# Altium schematic sheet CUBETTO-PT100-DA-PTC-.SchDoc(CUBETTO-PT100-DA-PTC-)
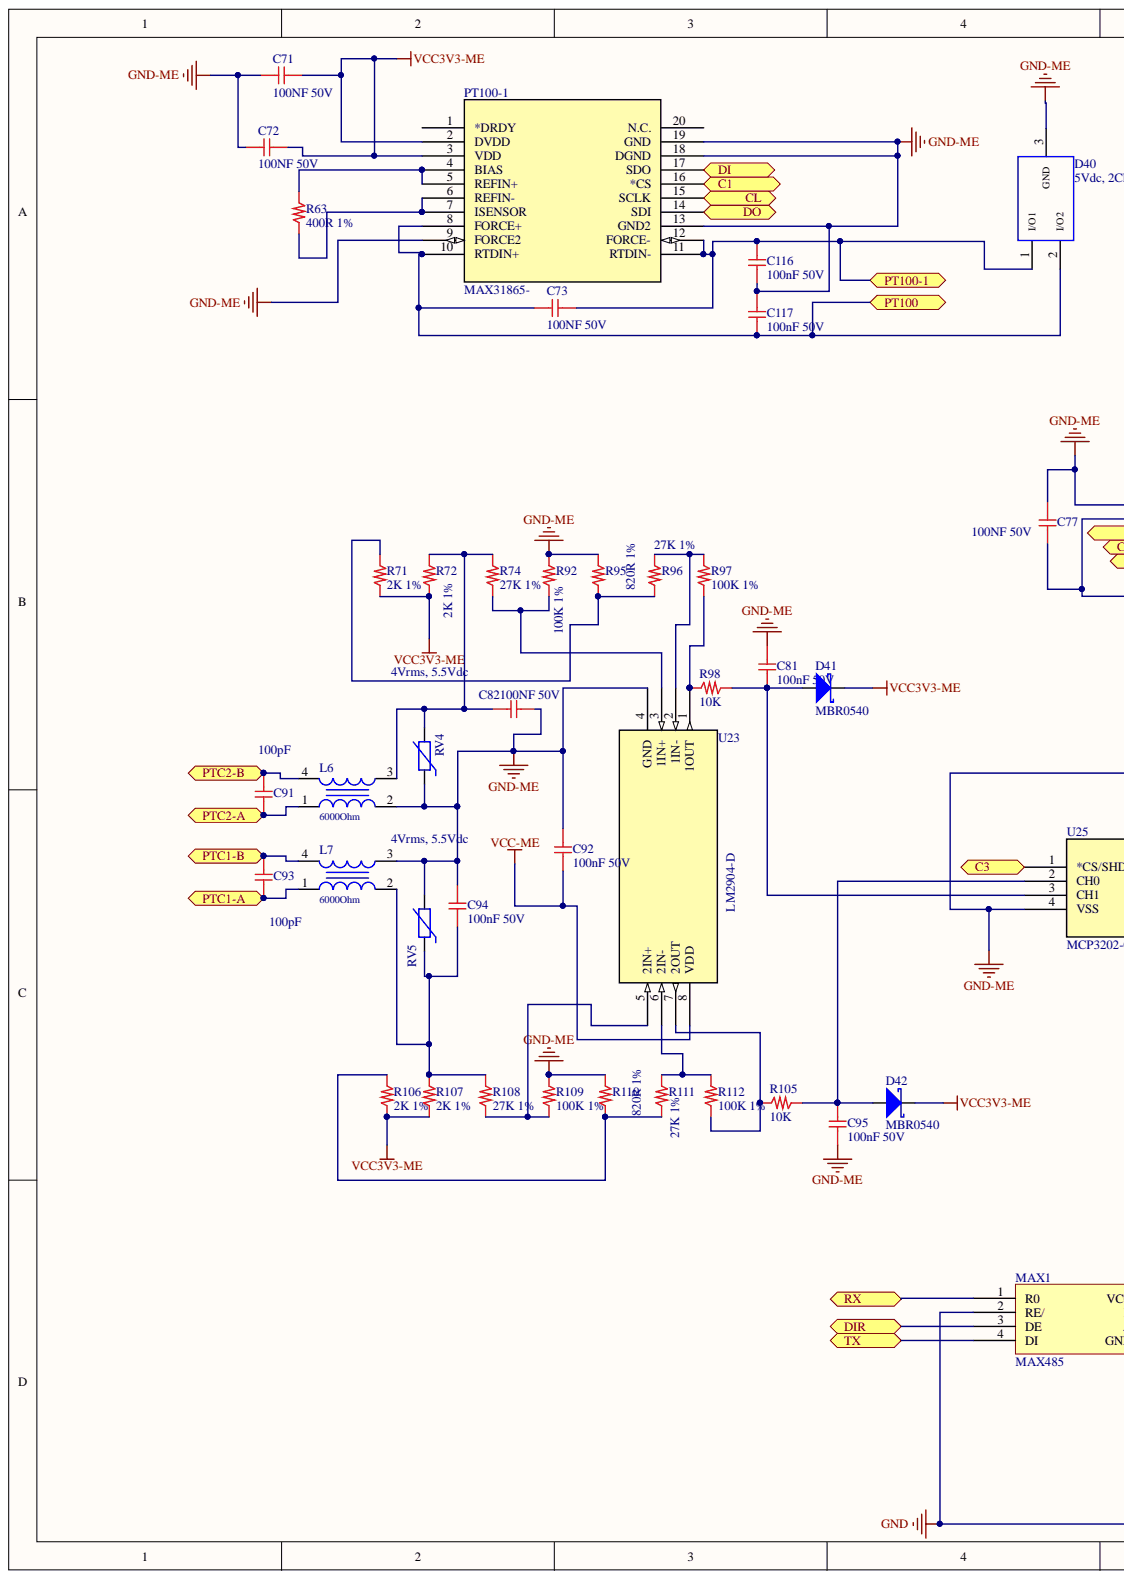
[diagram p0: CUBETTO-PT100-DA-PTC-.SchDoc, left]
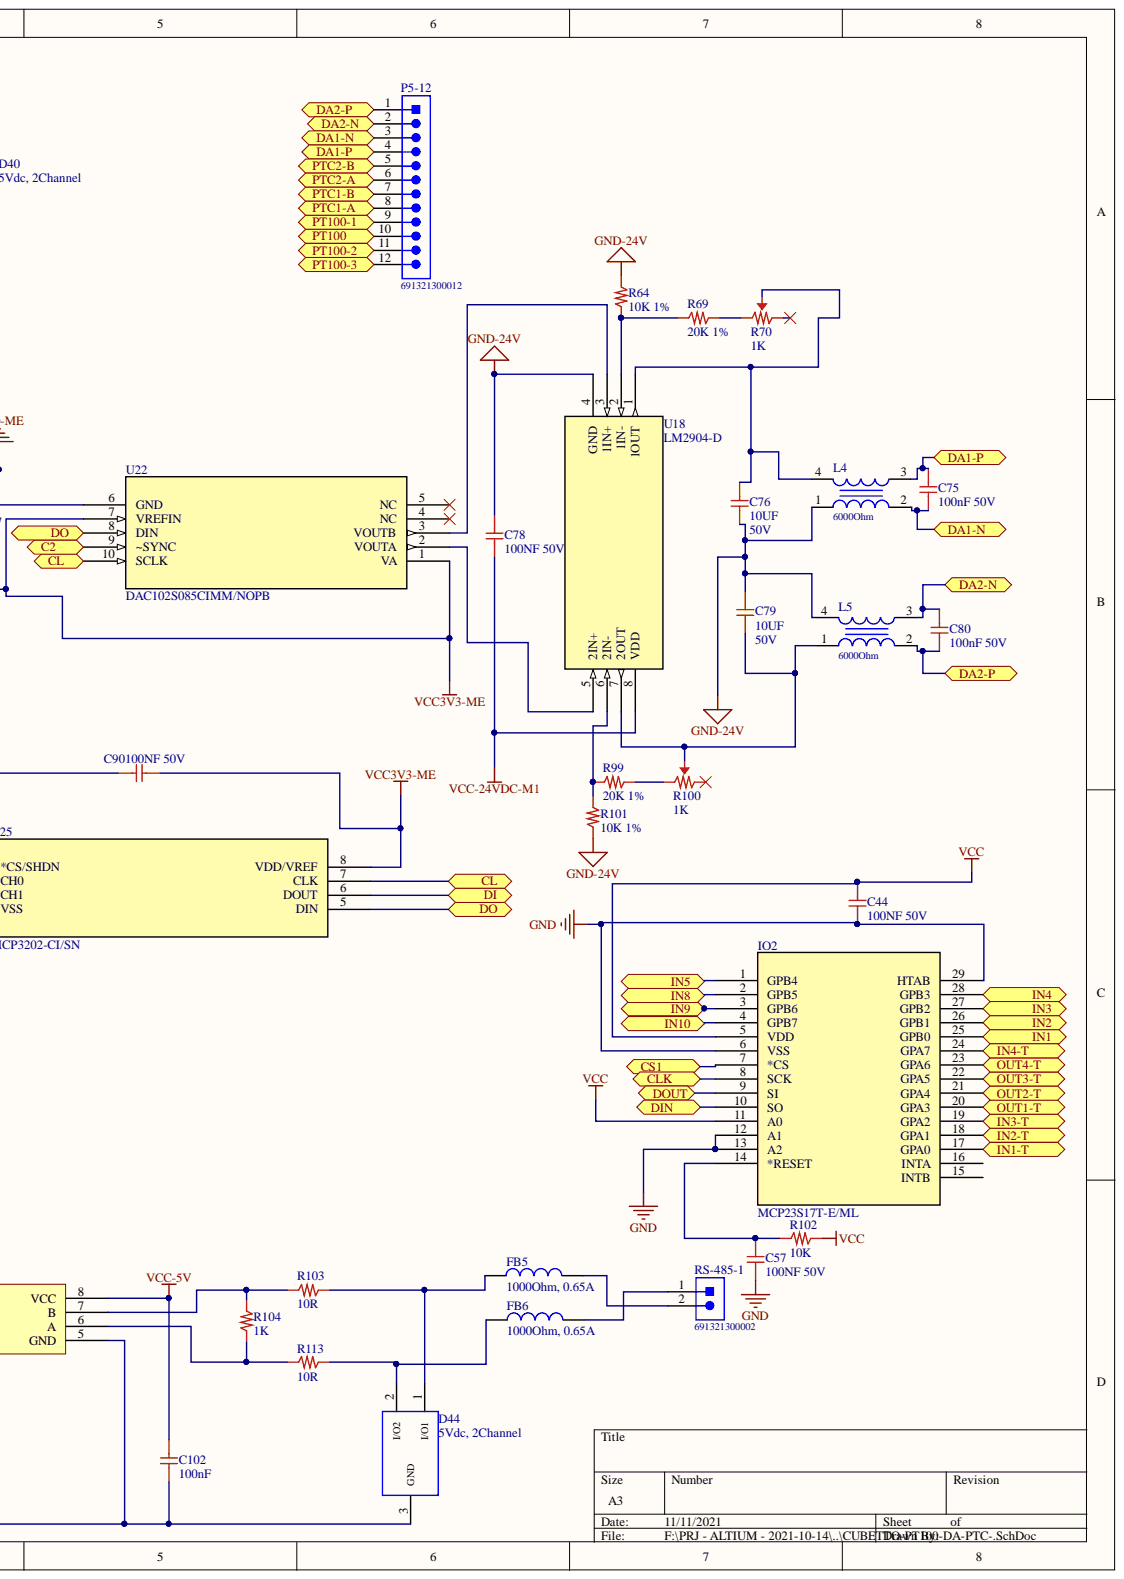
[diagram p1: CUBETTO-PT100-DA-PTC-.SchDoc, right]

## Parts
C44  100NF 50V  [0603_c]
C57  100NF 50V  [0603_c]
C71  100NF 50V  [0603_c]
C72  100NF 50V  [0603_c]
C73  100NF 50V  [0603_c]
C75  100nF 50V  [0603_c]
C76  10UF  Tensione: 50V  [1206_C]
C77  100NF 50V  [0603_c]
C78  100NF 50V  [0603_c]
C79  10UF  Tensione: 50V  [1206_C]
C80  100nF 50V  [0603_c]
C81  100nF 50V  [0603_c]
C82  100NF 50V  [0603_c]
C90  100NF 50V  [0603_c]
C91  100pF  [0603_c]
C92  100nF 50V  [0603_c]
C93  100pF  [0603_c]
C94  100nF 50V  [0603_c]
C95  100nF 50V  [0603_c]
C102  100nF  [0805_C]
C116  100nF 50V  [0603_c]
C117  100nF 50V  [0603_c]
D40  5Vdc, 2Channel  [WE-TVS_Standard_SOT23-3L]
D41  MBR0540  [SOD123]
D42  MBR0540  [SOD123]
D44  5Vdc, 2Channel  [WE-TVS_Standard_SOT23-3L]
FB5  1000Ohm, 0.65A  Rated Current: 0.65A  [WE-CBF_0603_Wide Band]
FB6  1000Ohm, 0.65A  Rated Current: 0.65A  [WE-CBF_0603_Wide Band]
IO2  MCP23S17T-E/ML  [QFN28_6x6MC]
L4  6000Ohm  [WE-CNSW_1206]
L5  6000Ohm  [WE-CNSW_1206]
L6  6000Ohm  [WE-CNSW_1206]
L7  6000Ohm  [WE-CNSW_1206]
MAX1  MAX485  [SO-8]
P5-12  691321300012  [691321300012]
PT100-1  MAX31865-  [SSO-20]
R63  400R 1%  [0805_R]
R64  10K 1%  [0603_R]
R69  20K 1%  [0603_R]
R70  1K  [ResTrimSuntan5X10X10]
R71  2K 1%  [0603_R]
R72  2K 1%  [0603_R]
R74  27K 1%  [0603_R]
R92  100K 1%  [0603_R]
R95  820R 1%  [0603_R]
R96  27K 1%  [0603_R]
R97  100K 1%  [0603_R]
R98  10K  [0603_R]
R99  20K 1%  [0603_R]
R100  1K  [ResTrimSuntan5X10X10]
R101  10K 1%  [0603_R]
R102  10K  [0603_R]
R103  10R  [0805_R]
R104  1K  [0805_R]
R105  10K  [0603_R]
R106  2K 1%  [0603_R]
R107  2K 1%  [0603_R]
R108  27K 1%  [0603_R]
R109  100K 1%  [0603_R]
R110  820R 1%  [0603_R]
R111  27K 1%  [0603_R]
R112  100K 1%  [0603_R]
R113  10R  [0805_R]
RS-485-1  691321300002  [691321300002]
RV4  4Vrms, 5.5Vdc  [WE-VS_0603]
RV5  4Vrms, 5.5Vdc  [WE-VS_0603]
U18  LM2904-D  [SO-8]
U22  DAC102S085CIMM/NOPB  [MUB10A]
U23  LM2904-D  [SO-8]
U25  MCP3202-CI/SN  [SOIC8-N_MC]

## Ports
C1, C2, C3, CL, CLK, CS1, DA1-N, DA1-P, DA2-N, DA2-P, DI, DIN, DIR, DO, DOUT, IN1, IN1-T, IN2, IN2-T, IN3, IN3-T, IN4, IN4-T, IN5, IN8, IN9, IN10, OUT1-T, OUT2-T, OUT3-T, OUT4-T, PT100, PT100-1, PT100-2, PT100-3, PTC1-A, PTC1-B, PTC2-A, PTC2-B, RX, TX

## Nets
GND: C44.1 C57.1 C102.2 D44.3 IO2.6(VSS) IO2.12(A1) IO2.13(A2) IO2.29(HTAB) MAX1.2(RE/) MAX1.5(GND)
GND-24V: C76.2 C78.1 C79.1 L4.1 L5.4 R64.1 R101.2 U18.4(GND)
GND-ME: C71.2 C72.2 C77.1 C81.1 C82.2 C90.1 C92.1 C94.1 C95.2 C116.2 C117.1 D40.3 L6.2 L7.3 PT100-1.9(FORCE2) PT100-1.13(GND2) PT100-1.18(DGND) PT100-1.19(GND) R92.2 R95.2 R109.2 R110.2 RV4.1 RV5.2 U22.6(GND) U23.4(GND) U25.4(VSS)
VCC: C44.2 IO2.5(VDD) IO2.11(A0) R102.2
VCC3V3-ME: C71.1 C72.1 C77.2 C90.2 D41.2 D42.2 PT100-1.2(DVDD) PT100-1.3(VDD) R71.1 R72.1 R106.1 R107.1 U22.1(VA) U22.7(VREFIN) U25.8(VDD/VREF)
VCC-5V: C102.1 MAX1.8(VCC)
VCC-24VDC-M1: C78.2 U18.8(VDD)
VCC-ME: C92.2 U23.8(VDD)
C1: PT100-1.16(*CS) ; port C1
C2: U22.9(~SYNC) ; port C2
C3: U25.1(*CS/SHDN) ; port C3
CL: PT100-1.15(SCLK) U22.10(SCLK) U25.7(CLK) ; port CL
CLK: IO2.8(SCK) ; port CLK
CS1: IO2.7(*CS) ; port CS1
DA1-N: C75.2 L4.2 P5-12.3 ; port DA1-N
DA1-P: C75.1 L4.3 P5-12.4 ; port DA1-P
DA2-N: C80.1 L5.3 P5-12.2 ; port DA2-N
DA2-P: C80.2 L5.2 P5-12.1 ; port DA2-P
DI: PT100-1.17(SDO) U25.6(DOUT) ; port DI
DIN: IO2.10(SO) ; port DIN
DIR: MAX1.3(DE) ; port DIR
DO: PT100-1.14(SDI) U22.8(DIN) U25.5(DIN) ; port DO
DOUT: IO2.9(SI) ; port DOUT
IN1: IO2.25(GPB0) ; port IN1
IN1-T: IO2.17(GPA0) ; port IN1-T
IN2: IO2.26(GPB1) ; port IN2
IN2-T: IO2.18(GPA1) ; port IN2-T
IN3: IO2.27(GPB2) ; port IN3
IN3-T: IO2.19(GPA2) ; port IN3-T
IN4: IO2.28(GPB3) ; port IN4
IN4-T: IO2.24(GPA7) ; port IN4-T
IN5: IO2.1(GPB4) ; port IN5
IN8: IO2.2(GPB5) ; port IN8
IN9: IO2.3(GPB6) ; port IN9
IN10: IO2.4(GPB7) ; port IN10
OUT1-T: IO2.20(GPA3) ; port OUT1-T
OUT2-T: IO2.21(GPA4) ; port OUT2-T
OUT3-T: IO2.22(GPA5) ; port OUT3-T
OUT4-T: IO2.23(GPA6) ; port OUT4-T
PT100: C73.2 C117.2 D40.2 P5-12.10 PT100-1.8(FORCE+) PT100-1.10(RTDIN+) ; port PT100
PT100-1: C73.1 C116.1 D40.1 P5-12.9 PT100-1.11(RTDIN-) PT100-1.12(FORCE-) ; port PT100-1
PT100-2: P5-12.11 ; port PT100-2
PT100-3: P5-12.12 ; port PT100-3
PTC1-A: C93.2 L7.1 P5-12.8 ; port PTC1-A
PTC1-B: C93.1 L7.4 P5-12.7 ; port PTC1-B
PTC2-A: C91.2 L6.1 P5-12.6 ; port PTC2-A
PTC2-B: C91.1 L6.4 P5-12.5 ; port PTC2-B
RX: MAX1.1(R0) ; port RX
TX: MAX1.4(DI) ; port TX
NetC57_2: C57.2 IO2.14(*RESET) R102.1
NetC76_1: C76.1 L4.4 R70.2 U18.1(1OUT)
NetC79_2: C79.2 L5.1 R100.2 U18.7(2OUT)
NetC81_2: C81.2 D41.1 R98.1 U25.3(CH1)
NetC82_1: C82.1 L6.3 R72.2 R74.2 RV4.2
NetC94_2: C94.2 L7.2 R107.2 R108.2 RV5.1
NetC95_1: C95.1 D42.1 R105.1 U25.2(CH0)
NetD44_1: D44.1 FB5.1 R103.2
NetD44_2: D44.2 FB6.1 R113.2
NetFB5_2: FB5.2 RS-485-1.2
NetFB6_2: FB6.2 RS-485-1.1
NetMAX1_6: MAX1.6(A) R104.1 R113.1
NetMAX1_7: MAX1.7(B) R103.1 R104.2
NetPT100-1_4: PT100-1.4(BIAS) PT100-1.5(REFIN+) R63.1
NetPT100-1_6: PT100-1.6(REFIN-) PT100-1.7(ISENSOR) R63.2
NetR64_2: R64.2 R69.1 U18.2(1IN-)
NetR69_2: R69.2 R70.1
NetR71_2: R71.2 R95.1 R96.1
NetR74_1: R74.1 R92.1 U23.3(1IN+)
NetR96_2: R96.2 R97.2 U23.2(1IN-)
NetR97_1: R97.1 R98.2 U23.1(1OUT)
NetR99_1: R99.1 R101.1 U18.6(2IN-)
NetR99_2: R99.2 R100.1
NetR105_2: R105.2 R112.1 U23.7(2OUT)
NetR106_2: R106.2 R110.1 R111.1
NetR108_1: R108.1 R109.1 U23.5(2IN+)
NetR111_2: R111.2 R112.2 U23.6(2IN-)
NetU18_3: U18.3(1IN+) U22.3(VOUTB)
NetU18_5: U18.5(2IN+) U22.2(VOUTA)

Not connected: IO2 15(INTB) 16(INTA); PT100-1 1(*DRDY) 20(N.C.); R70 3; R100 3; U22 4(NC) 5(NC)

## Library data of the parts
C44 C57 C71-C73 C75 C77 C78 C80-C82 C90 C92 C94 C95 C116 C117 = 100NF 50V: Cap X7R 100nF 50V Flex Terminal; Produttore: Samsung; Cod. Produttore: CL10B104KB8SFNC; Cod. Interno: COCS50665050104; Library Name: CapSMD0603.SchLib; Component Kind: Standard; Cod. DigiKey: 1276-1936-2-ND
C76 C79 = 10UF 50V: Cap X5R 10UF 50V; Produttore: MURATA; Cod. Produttore: GRM31CR61H106KA12L; Temperature Range: Da -55°C a +125°C; Library Name: CapSMD1206.SchLib; Component Kind: Standard; Cod. Farnell: 2666583
C91 C93 = 100pF 50V: Cap X7R 100pF 50V; Produttore: ??; Cod. Interno: COCS??; Library Name: CapSMD0603.SchLib; Component Kind: Standard; Cod. Produttore: ??
C102 = 100nF 100V: Cap X7R 100nF 100V; Produttore: ??; Cod. Interno: COCS 557051010104; Library Name: CapSMD0805.SchLib; Component Kind: Standard; Cod. Produttore: ??
D40 D44 = 824022: WE-TVS TVS Diode - Standard Series, SOT23-3L, 5Vdc, 2 Channel; Reverse Peak Pulse Current: 8A; Reverse Channel Leakage Current: 2.5uA; Reverse Breakdown Voltage min.: 6.1V; DC Operating Voltage: 5V; Channel Input Capacitance: 12pF; Channel: 2; Size: SOT23-3L; Published Date: June 2019; Part Number: 824022; Packaging: Tape and Reel; Operating Temperature: -55 to +125degC; Mounting Technology: SMT; Library Name: WE-TVS.SchLib; ComponentLink2URL: https://katalog.we-online.com/pbs/datasheet/824022.pdf; ComponentLink2Description: Datasheet; ComponentLink1URL: http://www.we-online.de; ComponentLink1Description: Manufacturer; Component Kind: Standard; Publisher: Wurth Elektronik
D41 D42 = MBR0540: Schottky Diode; Simulation: SKYDIODE; Signal Integrity: D Schottky; Published: 8-Jun-2000; PackageReference: SMB; PackageDescription: DO-214-AA/SMB; 2 C-Bend Leads; Body 5.3 x 3.6 mm, inc. leads (LxW); LatestRevisionNote: Re-released for DXP Platform.; LatestRevisionDate: 17-Jul-2002; Component Kind: Standard; Code_JEDEC: DO-214-AA; Publisher: Altium Limited; Library Name: HSW.SchLib
FB5 FB6 = 74279266: WE-CBF SMT EMI Suppression Ferrite Bead, size 0603, 1000Ohm, 0.65A; Type: Wide Band; Impedance max.: 1350Ohm; DC Resistance max.: 0.6Ohm; Size: 0603; Published Date: March 2019; Part Number: 74279266; Packaging: Tape and Reel; Operating Temperature: -55 to +125degC; Mounting Technology: SMT; Library Name: WE-CBF_0603.SchLib; Impedance@100 MHz: 1000Ohm; ComponentLink2URL: https://katalog.we-online.com/pbs/datasheet/74279266.pdf; ComponentLink2Description: Datasheet; ComponentLink1URL: http://www.we-online.de; ComponentLink1Description: Manufacturer; Component Kind: Standard; Publisher: Wurth Elektronik
IO2 = MCP23S17T-E/ML: SPI-IO 16IO; Vendor: Microchip; SourceLibrary: Microchip_2019-08-23_9; Built_By: EMA_Jessica; Type: DEV; RefDes: RefDes; Manufacturer_Part_Number: MCP23S17T-E/ML; Component Kind: Standard; Library Name: HSW.SchLib; Copyright: Copyright (C) 2018 Accelerated Designs. All rights reserved
L4-L7 = 744232101: WE-CNSW SMT Common Mode Line Filter, size 1206, 6000Ohm, 0.09A, 50V; Manufacturer: Wurth Elektronik; Winding Style: bifiliar; Insulation Test Voltage: 125VAC; Impedance Test Condition: 100MHz; DC Resistance max.: 5.5Ohm; Size: 1206; Rated Voltage: 50V; Rated Current: 0.09A; Published Date: April 2020; Packaging: Tape and Reel; Operating Temperature: -40 to +125degC; Mounting Technology: SMT; Manufacturer Part Number: 744232101; Library Name: WE-CNSW_1206.SchLib; Impedance: 6000Ohm; ComponentLink2URL: https://www.we-online.com/catalog/datasheet/744232101.pdf; ComponentLink2Description: Datasheet; ComponentLink1URL: http://www.we-online.de; ComponentLink1Description: Manufacturer; Component Kind: Standard
P5-12 = 691321300012: Serie 321 - 3.81mm Vertical PCB Header WR-TBL, 12 pin; Pin Number: 12; Gender: Male; Published Date: June 2018; Pitch: 3.81 mm; Part Number: 691321300012; Packaging: Box; Operating Temperature: -40 to 105 degC; Mounting Technology: THT; Library Name: Pluggable PCB System WR-TBL_3.81 mm.SchLib; ComponentLink2URL: http://katalog.we-online.de/em/datasheet/6913213000xx.pdf; ComponentLink2Description: Datasheet; ComponentLink1URL: http://www.we-online.de; ComponentLink1Description: Manufacturer; Component Kind: Standard; Publisher: Wurth Elektronik
PT100-1 = MAX31865AAP+T: PT100-PT1000- SENSOR TEMPERATURE; Vendor: Maxim Integrated Products; Built_By: EMA_UL_Team; Value: Value; Type: DEV; RefDes: RefDes; Manufacturer_Part_Number: MAX31865AAP+T; Component Kind: Standard; Library Name: HSW.SchLib; Copyright: Copyright (C) 2018 Accelerated Designs. All rights reserved
R63 = 400R 1%: Res Film Spesso 100mW 400R 1%; V nominale: 100V; Produttore: Panasonic; Cod. Produttore: ERA6AEB301V; Cod. Interno: ??; Potenza: 100mW; Library Name: ResSMD0805.SchLib; Component Kind: Standard; Cod. Farnell: 1670221
R64 R69 R99 R101 = 1K 1%: Res Film Spesso 1/16W 1K 1%; Produttore: ??; Cod. Interno: RESS4166356B0102; Library Name: ResSMD0603.SchLib; Component Kind: Standard; Cod. Produttore: ??
R70 R100 = 10K POT.V: Multiturn Trimmer; Produttore: Suntan; Component Kind: Standard; Cod. Produttore: TSR-3296; Library Name: ResTrimPTH.SchLib
R71 R72 R74 R92 R95-R98 R105-R112 = 2K7: Res Film Spesso 1/16W 2K7 5%; Produttore: VISHAY; Cod. Produttore: CRCW06032K70JNEA; Cod. Interno: RESS4166556B0272; Library Name: ResSMD0603.SchLib; Component Kind: Standard
R102 = 10K: Res Film Spesso 1/16W 10K 5%; Produttore: ??; Cod. Interno: RESS4166556B0103??; Library Name: ResSMD0603.SchLib; Component Kind: Standard; Cod. Produttore: ??
R103 R113 = 10R: Res Film Spesso 1/8W 10R 5%; Potenza: 1/8W 5%; Cod. Interno: RESS4170555B0100; Library Name: ResSMD0805.SchLib; Component Kind: Standard
R104 = 1K: Res Film Spesso 1/2W 1K 5%; Potenza: 1/2W 5%; Cod. Interno: RESS4170555B0122??; Library Name: ResSMD0805.SchLib; Component Kind: Standard
RS-485-1 = 691321300002: Serie 321 - 3.81mm Vertical PCB Header WR-TBL, 2 pin; Pin Number: 2; Gender: Male; Published Date: June 2018; Pitch: 3.81 mm; Part Number: 691321300002; Packaging: Box; Operating Temperature: -40 to 105 degC; Mounting Technology: THT; Library Name: Pluggable PCB System WR-TBL_3.81 mm.SchLib; ComponentLink2URL: http://katalog.we-online.de/em/datasheet/6913213000xx.pdf; ComponentLink2Description: Datasheet; ComponentLink1URL: http://www.we-online.de; ComponentLink1Description: Manufacturer; Component Kind: Standard; Publisher: Wurth Elektronik
RV4 RV5 = 82536040: WE-VS SMD Varistor, size 0603, 4Vrms, 5.5Vdc; Withstanding Surge Current: 30A; Varistor Voltage: 8V; Energy Dissipation: 0.1J; Clamping Voltage: 21V; Size: 0603; Published Date: March 2019; Part Number: 82536040; Packaging: Tape and Reel; Operating Temperature: -40 to +85degC; Mounting Technology: SMT; Library Name: WE-VS_0603.SchLib; DC Operating Voltage: 5.5Vdc; ComponentLink2URL: https://katalog.we-online.com/pbs/datasheet/82536040.pdf; ComponentLink2Description: Datasheet; ComponentLink1URL: http://www.we-online.de; ComponentLink1Description: Manufacturer; Component Kind: Standard; AC Operating Voltage: 4Vrms; Publisher: Wurth Elektronik
U18 U23 = OPA2196: No Description Available; Manufacturer_Part_Number: TLC272CDR; Vendor: Texas Instruments; Built_By: EMA_UL_Team; Value: Value; Type: DEV; RefDes: RefDes; Component Kind: Standard; Library Name: OPERAZIONALI.SchLib; Copyright: Copyright (C) 2018 Accelerated Designs. All rights reserved
U22 = DAC102S085CIMM/N: No Description Available; Vendor: Texas Instruments; Built_By: EMA_UL_Team; Value: Value; Type: DEV; RefDes: RefDes; Manufacturer_Part_Number: DAC102S085CIMM/NOPB; Library Name: 2019-02-07_18-39-28.SchLib; Component Kind: Standard; Copyright: Copyright (C) 2018 Accelerated Designs. All rights reserved
U25 = MCP3202-CI/SN: No Description Available; Vendor: Microchip; Built_By: EMA_UL_Team; Value: Value; Type: DEV; RefDes: RefDes; Manufacturer_Part_Number: MCP3202-CI/SN; Library Name: MCP3202.SchLib; Component Kind: Standard; Copyright: Copyright (C) 2018 Accelerated Designs. All rights reserved
MAX1 = MAX485: Component Kind: Standard; Library Name: HSW.SchLib
